# Altium schematic sheet Buzzer_and_Solenoid.SchDoc
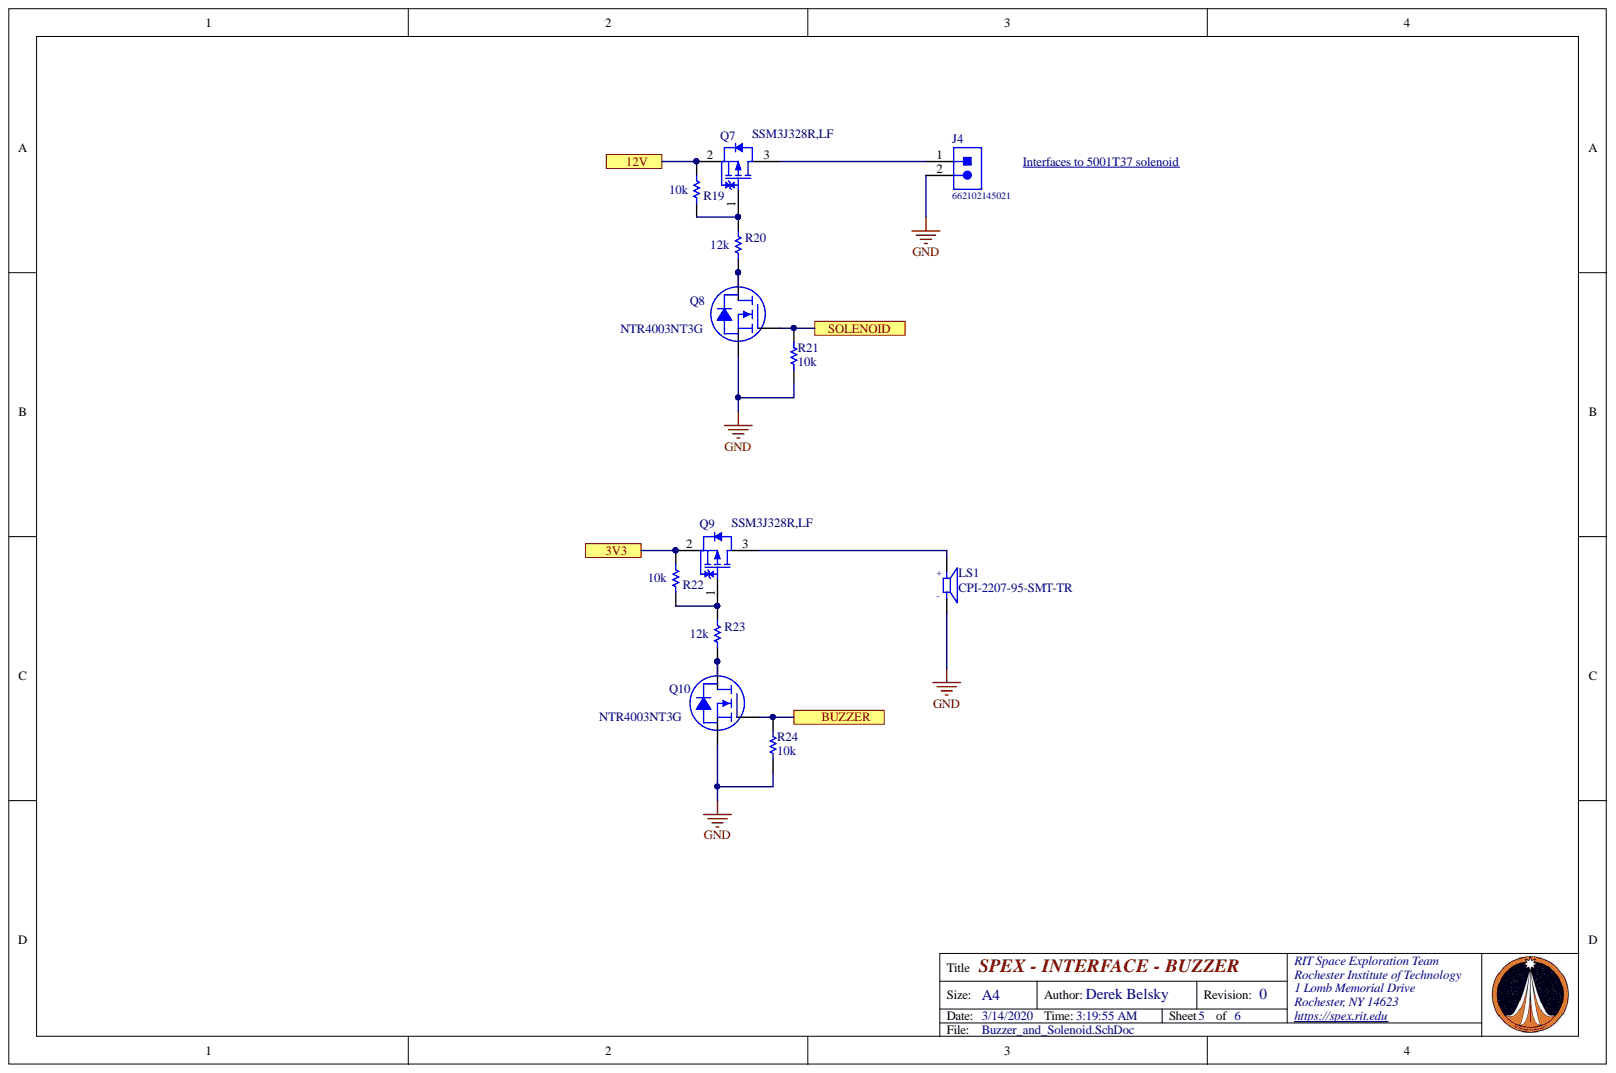
[diagram p0: Buzzer_and_Solenoid.SchDoc]

## Parts
J4  662102145021  [662102145021]
LS1  CPI-2207-95-SMT-TR  [XDCR_CPI-2207-95-SMT-TR]
Q7  SSM3J328R,LF  [TOSH-SOT-23F_V]
Q8  NTR4003NT3G  [ON SEMI SOT-23-3]
Q9  SSM3J328R,LF  [TOSH-SOT-23F_V]
Q10  NTR4003NT3G  [ON SEMI SOT-23-3]
R19  value 10k  [RESC1609X50X30NL8T20]  (hidden comment: CRCW060310K0FKEA)
R20  value 12k  [RESC1609X50X30LL10T20]  (hidden comment: CRCW060312K0FKEA)
R21  value 10k  [RESC1609X50X30NL8T20]  (hidden comment: CRCW060310K0FKEA)
R22  value 10k  [RESC1609X50X30NL8T20]  (hidden comment: CRCW060310K0FKEA)
R23  value 12k  [RESC1609X50X30LL10T20]  (hidden comment: CRCW060312K0FKEA)
R24  value 10k  [RESC1609X50X30NL8T20]  (hidden comment: CRCW060310K0FKEA)

## Ports
3V3, 12V, BUZZER, SOLENOID

## Nets
GND: J4.2 LS1.N Q8.2 Q10.2 R21.2 R24.2
3V3: Q9.2 R22.2 ; port 3V3
12V: Q7.2 R19.2 ; port 12V
BUZZER: Q10.1 R24.1 ; port BUZZER
SOLENOID: Q8.1 R21.1 ; port SOLENOID
NetJ4_1: J4.1 Q7.3
NetLS1_P: LS1.P Q9.3
NetQ7_1: Q7.1 R19.1 R20.2
NetQ8_3: Q8.3 R20.1
NetQ9_1: Q9.1 R22.1 R23.2
NetQ10_3: Q10.3 R23.1

## Library data of the parts
J4 = CMP-1502-00482-1: SMT Male Header WR-MPC3, with SMT Solder Tabs, Right Angled, Single Row, pitch 3 mm, 2 pins; Manufacturer: Wurth Elektronik; Contact Resistance: 10mOhm; Pin Number: 2; Pitch: 3mm; Working Voltage: 250VAC; Component Kind: Standard; Datasheet URL: https://katalog.we-online.de/em/datasheet/6621xx145021.pdf; Gender: Male; Library Name: Altium Content Vault; Manufacturer URL: http://www.we-online.de; Mounting Technology: SMT; Operating Temperature: -40to105degC; Orientation: RightAngled; Package Reference: 662102145021; Packaging: TapeandReel; Part Number: 662102145021; Publisher: WurthElektronik
LS1 = CPI-2207-95-SMT-TR: 22.1 mm, 12 Vdc, 95 dB, Surface Mount, Driving Circuit, Piezo Audio Indicator Buzzer; MF: CUI Devices; Component Kind: Standard; CUI_purchase_URL: https://www.cuidevices.com/product/audio/buzzers/audio-indicators/cpi-2207-95-smt-tr?utm_source=snapeda.com&utm_medium=referral&utm_campaign=snapedaBOM; Digi-Key_Part_Number: 2223-CPI-2207-95-SMT-CT-ND; Digi-Key_Purchase_URL: https://www.digikey.com/product-detail/en/cui-devices/CPI-2207-95-SMT-TR/2223-CPI-2207-95-SMT-CT-ND/11591340?utm_source=snapeda&utm_medium=aggregator&utm_campaign=symbol; Library Name: CPI-2207-95-SMT-TR.IntLib; MP: CPI-2207-95-SMT-TR; Package: CUI Devices; SnapEDA_Link: https://www.snapeda.com/parts/CPI-2207-95-SMT-TR/CUI%20Devices/view-part/4502466/?ref=snap
Q7 Q9 = CMP-2100-03686-1: TOSHIBA Field-Effect Transistor Silicon P-Channel MOS Type (U-MOS VI), 20 V, -55 to 125 degC, 3-Pin SOTFL, RoHS, Tape and Reel; Continuous Drain Current (ID): 6A; Drain to Source Breakdown Voltage: -20V; Drain to Source Resistance: 47.4mOhm; Drain to Source Voltage (Vdss): 20V; Element Configuration: Single; Gate to Source Voltage (Vgs): 8V; Input Capacitance: 840pF; Max Power Dissipation: 1W; Number of Channels: 1; Power Dissipation: 2W; Rds On Max: 29.8mOhm; Turn-Off Delay Time: 107ns; Turn-On Delay Time: 32ns; Case/Package: SOT-23-3; Component Kind: Standard; Datasheet URL: https://toshiba.semicon-storage.com/info/docget.jsp?did=2429&prodName=SSM3J328R; Datasheet Version: 41699.0; Library Name: Altium Content Vault; Manufacturer: Toshiba; Manufacturer URL: http://www.toshiba.com/; Max Operating Temperature: 150degC; Min Operating Temperature: -55degC; Mount: SurfaceMount; Number of Elements: 1; Number of Pins: 3; Package Description: 3-Pin Small Outline Transistor, Flat Lead, Body 2.9 x 1.8 mm, Pitch 0.95 mm; Package Quantity: 3000; Package Reference: SOT-23F; Package URL: https://toshiba.semicon-storage.com/ap-en/design-support/package/detail.SOT-23F.html; Packaging: TapeandReel; Radiation Hardening: No; RoHSCompliant: true
Q8 Q10 = NTR4003NT3G: MOSFET N-CH 30V 500MA SOT-23; Manufacturer: ON Semiconductor; Current - Continuous Drain (Id) @ 25°C: 500mA (Ta); Database Table Name: MOSFET - Single - N-Ch; Drive Voltage (Max Rds On,  Min Rds On): 2.5V, 4V; FET Type: N-Channel; Gate Charge (Qg) (Max) @ Vgs: 1.15nC @ 5V; Input Capacitance (Ciss) (Max) @ Vds: 21pF @ 5V; Power Dissipation (Max): 690mW (Ta); Rds On (Max) @ Id, Vgs: 1.5Ohm @ 10mA, 4V; Vgs (Max): ±20V; Vgs(th) (Max) @ Id: 1.4V @ 250µA; Component Kind: Standard; ComponentLink1Description: Datasheet; ComponentLink1URL: http://www.onsemi.com/pub/Collateral/NTR4003N-D.PDF; ComponentLink2Description: DigiKey Link; ComponentLink2URL: http://digikey.com/product-detail/en/on-semiconductor/NTR4003NT3G/NTR4003NT3GOSCT-ND/1967271; Device Package: TO-236-3, SC-59, SOT-23-3; Drain to Source Voltage (Vdss): 30V; LastUpdated: 1/21/2020 4:01:12 AM; Library Name: Celestial Altium Library - altium_library.DbLib; Lifecycle Status: Active; Minimum Order: 1; Mounting Type: Surface Mount; Operating Temperature: -55°C ~ 150°C (TJ); Package / Case: TO-236-3, SC-59, SOT-23-3; Packaging: TapeAndReel; Part Number: NTR4003NT3G; Part Status: Active; Price: 0.04; Series: -; Supplier 1: DigiKey; Supplier Device Package: SOT-23-3 (TO-236); Supplier Part Number 1: NTR4003NT3GOSCT-ND; Technology: MOSFET (Metal Oxide)
R19 R21 R22 R24 = CMP-1666-00005-4: Chip Resistor, 10 KOhm, +/- 1%, 100 mW, -55 to 155 degC, 0603 (1608 Metric), RoHS, Tape and Reel; Manufacturer: Vishay Semiconductor; Voltage Rating: 75 V; Component Kind: Standard; ComponentLink1Description: Manufacturer URL; ComponentLink1URL: http://www.vishay.com/; ComponentLink2Description: Datasheet; ComponentLink2URL: https://datasheet.ciiva.com/11809/0900766b80d98afc-11809037.pdf; DatasheetVersion: 06/2012; Height: 0.45 mm; Length: 1.55 mm; Library Name: Altium Content Vault; Max Operating Temperature: 155 degC; Min Operating Temperature: -55 degC; Mounting Technology: Surface Mount; PackageDescription: 2-Pin Surface Mount Device, Body 1.575 x 0.85 mm; Package Quantity: 5000; PackageReference: 0603; Packaging: Tape and Reel; REACH SVHC: Unknown; RoHSCompliant: true
R20 R23 = CMP-2000-03877-1: Manufacturer: Vishay Dale; Component Kind: Standard; Library Name: Altium Content Vault; Part Number: CRCW060312K0FKEA
